# Altium schematic sheet STM32.SchDoc(STM32)
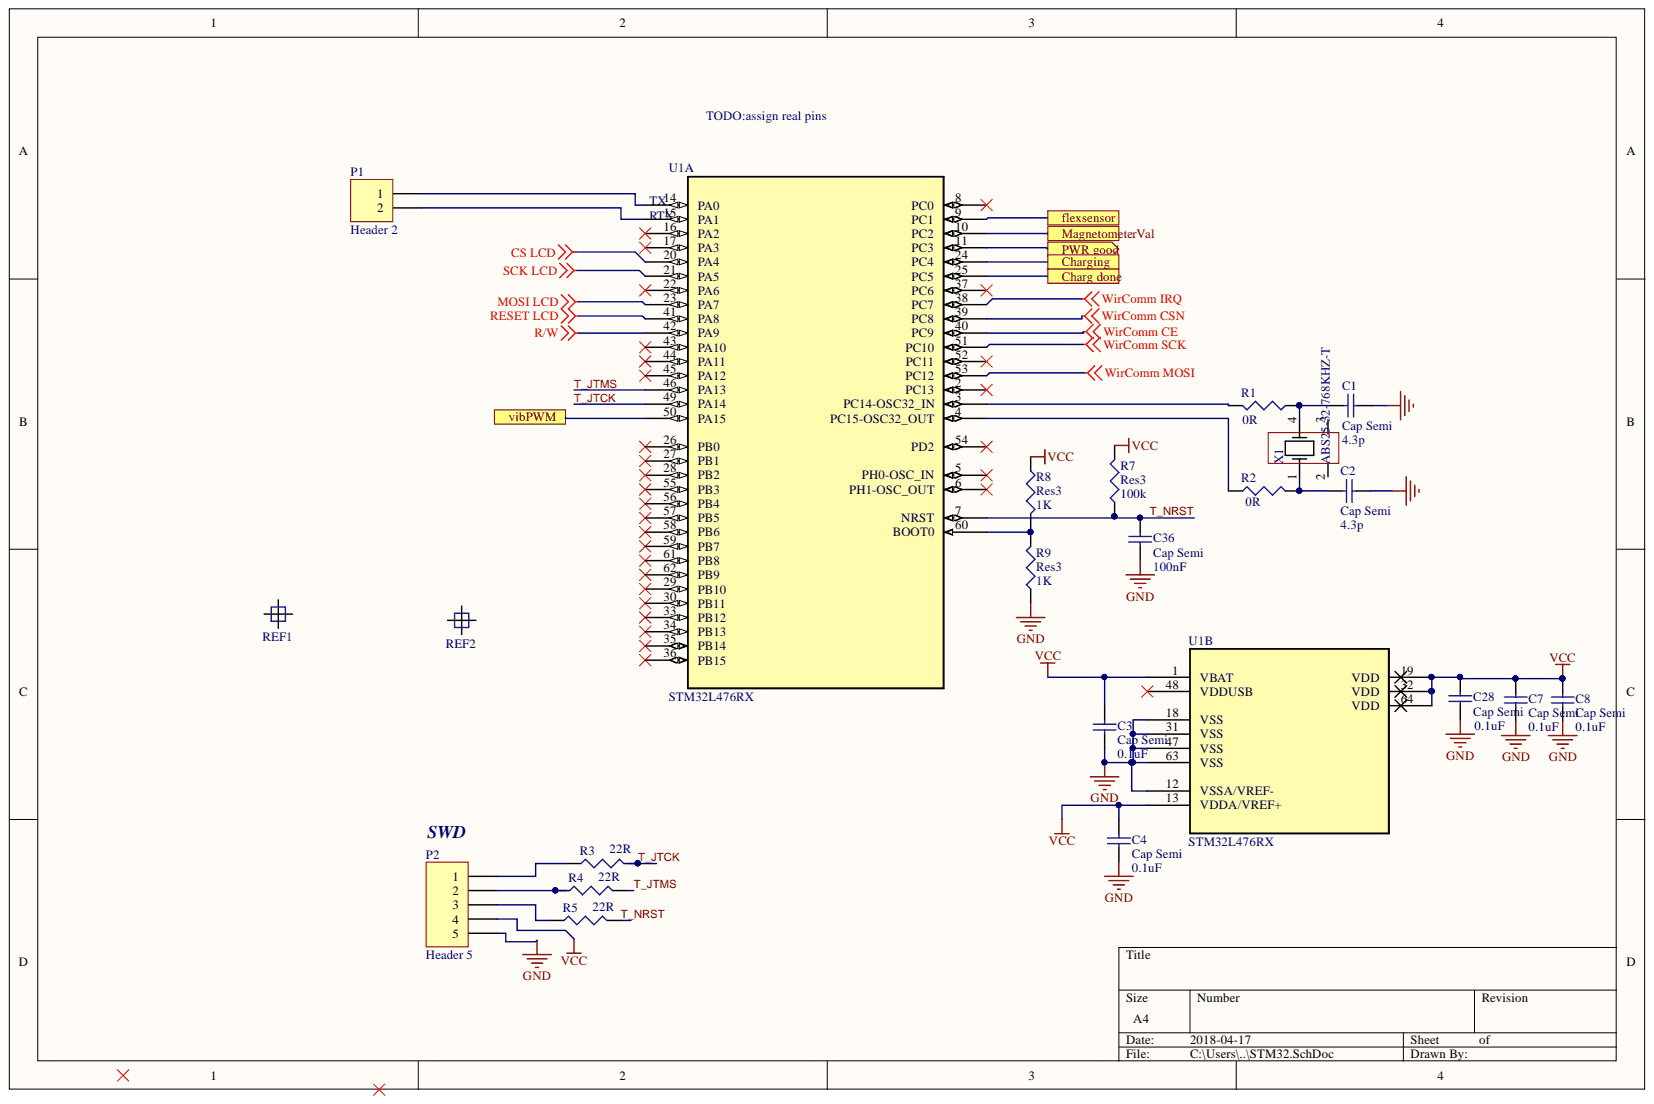
[diagram p0: STM32.SchDoc]

## Parts
C1  Cap Semi  value 4.3p  [1608[0603]]
C2  Cap Semi  value 4.3p  [1608[0603]]
C3  Cap Semi  value 0.1uF  [1608[0603]]
C4  Cap Semi  value 0.1uF  [1608[0603]]
C7  Cap Semi  value 0.1uF  [1608[0603]]
C8  Cap Semi  value 0.1uF  [1608[0603]]
C28  Cap Semi  value 0.1uF  [1608[0603]]
C36  Cap Semi  value 100nF  [1608[0603]]
P1  Header 2  [HDR1X2]
P2  Header 5  [HDR1X5]
R1  value 0R  [J1-0603]  (hidden comment: Res3)
R2  value 0R  [J1-0603]  (hidden comment: Res3)
R3  22R  [J1-0603]
R4  22R  [J1-0603]
R5  22R  [J1-0603]
R7  Res3  value 100k  [J1-0603]
R8  Res3  value 1K  [J1-0603]
R9  Res3  value 1K  [J1-0603]
REF1  Fudical sq.  [FIDUCIAL_SQ1mm_SQ5]  (value not drawn)
REF2  Fudical sq.  [FIDUCIAL_SQ1mm_SQ5]  (value not drawn)
U1  STM32L476RX  [LQFP64-10x10mm]  (parts A,B)
X1  ABS25-32-768KHZ-T  [XTAL_ABS25-32-768KHZ-T]

## Ports
Charg done, Charging, flexsensor, MagnetometerVal, PWR good, vibPWM

## Nets
CS LCD: U1.20(PA4)
GND: C1.1 C2.1 C3.1 C4.1 C7.1 C8.1 C28.1 C36.1 P2.5 R9.1 U1.12(VSSA/VREF-) U1.18(VSS) U1.31(VSS) U1.47(VSS) U1.63(VSS)
MOSI LCD: U1.23(PA7)
R/W: U1.42(PA9)
RESET LCD: U1.41(PA8)
SCK LCD: U1.21(PA5)
VCC: C3.2 C4.2 C7.2 C8.2 C28.2 P2.4 R7.2 R8.1 U1.1(VBAT) U1.13(VDDA/VREF+) U1.19(VDD) U1.32(VDD) U1.64(VDD)
WirComm CE: U1.40(PC9)
WirComm CSN: U1.39(PC8)
WirComm IRQ: U1.38(PC7)
WirComm MOSI: U1.53(PC12)
WirComm SCK: U1.51(PC10)
Charg done: U1.25(PC5) ; port Charg done
Charging: U1.24(PC4) ; port Charging
flexsensor: U1.9(PC1) ; port flexsensor
MagnetometerVal: U1.10(PC2) ; port MagnetometerVal
PWR good: U1.11(PC3) ; port PWR good
T_JTCK: R3.2 U1.49(PA14)
T_JTMS: R4.2 U1.46(PA13)
T_NRST: C36.2 R5.2 R7.1 U1.7(NRST)
vibPWM: U1.50(PA15) ; port vibPWM
NetC1_2: C1.2 R1.2 X1.4
NetC2_2: C2.2 R2.2 X1.1
NetP1_1: P1.1 U1.14(PA0)
NetP1_2: P1.2 U1.15(PA1)
NetP2_1: P2.1 R3.1
NetP2_2: P2.2 R4.1
NetP2_3: P2.3 R5.1
NetR1_1: R1.1 U1.3(PC14-OSC32_IN)
NetR2_1: R2.1 U1.4(PC15-OSC32_OUT)
NetR8_2: R8.2 R9.2 U1.60(BOOT0)

Not connected: U1 2(PC13) 5(PH0-OSC_IN) 6(PH1-OSC_OUT) 8(PC0) 16(PA2) 17(PA3) 22(PA6) 26(PB0) 27(PB1) 28(PB2) 29(PB10) 30(PB11) 33(PB12) 34(PB13) 35(PB14) 36(PB15) 37(PC6) 43(PA10) 44(PA11) 45(PA12) 48(VDDUSB) 52(PC11) 54(PD2) 55(PB3) 56(PB4) 57(PB5) 58(PB6) 59(PB7) 61(PB8) 62(PB9); X1 2 3

## Library data of the parts
C1 = Cap Semi: Capacitor (Semiconductor SIM Model); Value: 4.3p; Simulation: CAP; Signal Integrity: Cap Semi; Published: 8-Jun-2000; PackageReference: C1206; PackageDescription: Chip Capacitor; Library Name: Miscellaneous Devices.IntLib; LatestRevisionNote: IPC-7351 Footprint Added.; LatestRevisionDate: 29-May-2009; Component Kind: Standard; Publisher: Altium Limited
C2-C4 C7 C8 C28 C36 = Cap Semi: Capacitor (Semiconductor SIM Model); Simulation: CAP; Signal Integrity: Cap Semi; Published: 8-Jun-2000; PackageReference: C1206; PackageDescription: Chip Capacitor; Library Name: Miscellaneous Devices.IntLib; LatestRevisionNote: IPC-7351 Footprint Added.; LatestRevisionDate: 29-May-2009; Component Kind: Standard; Publisher: Altium Limited
P1 = Header 2: Header, 2-Pin; Signal Integrity: Connector; Library Name: Miscellaneous Connectors.IntLib; LatestRevisionNote: Re-released for DXP Platform.; LatestRevisionDate: 17-Jul-2002; Component Kind: Standard; Publisher: Altium Limited
P2 = Header 5: Header, 5-Pin; Signal Integrity: Connector; Library Name: Miscellaneous Connectors.IntLib; LatestRevisionNote: Re-released for DXP Platform.; LatestRevisionDate: 17-Jul-2002; Component Kind: Standard; Publisher: Altium Limited
R1 R2 R7-R9 = Res3: Resistor; Simulation: RESISTOR; Signal Integrity: Res; Published: 8-Jun-2000; PackageReference: J1-0603; PackageDescription: Chip Resistor; Library Name: Miscellaneous Devices.IntLib; LatestRevisionNote: IPC-7351 Footprint Added.; LatestRevisionDate: 29-May-2009; Component Kind: Standard; Publisher: Altium Limited
R3-R5 = Res3: Resistor; Value: 1K; Simulation: RESISTOR; Signal Integrity: Res; Published: 8-Jun-2000; PackageReference: J1-0603; PackageDescription: Chip Resistor; Library Name: Miscellaneous Devices.IntLib; LatestRevisionNote: IPC-7351 Footprint Added.; LatestRevisionDate: 29-May-2009; Component Kind: Standard; Publisher: Altium Limited
REF1 REF2 = Fudicalsquare: Fiducal square 1mm; Component Kind: Standard
  REF1: Library Name: HGCDLibrary2.IntLib
  REF2: Library Name: ScaleSCHlib1.SchLib
U1 = STM32L476RX: Imported; Vendor: ST Microelectronics; Value: Value; Type: Type; RefDes: RefDes; Manufacturer_Part_Number: stm32l476rx; Library Name: MainBoard.IntLib; Component Kind: Standard; Copyright: Copyright (C) 2016 Accelerated Designs. All rights reserved
X1 = ABS25-32-768KHZ-T: MF: Abracon; SnapEDA_Link: https://www.snapeda.com/symbols-footprints/ABS25-32-768KHZ-T/Abracon/view-part/?ref=snap; Price: None; Package: None; MP: ABS25-32-768KHZ-T; Component Kind: Standard; Availability: Unavailable; Library Name: HGCDLibrary.IntLib
